# Altium schematic sheet TopSheet.schdoc(TopSheet)
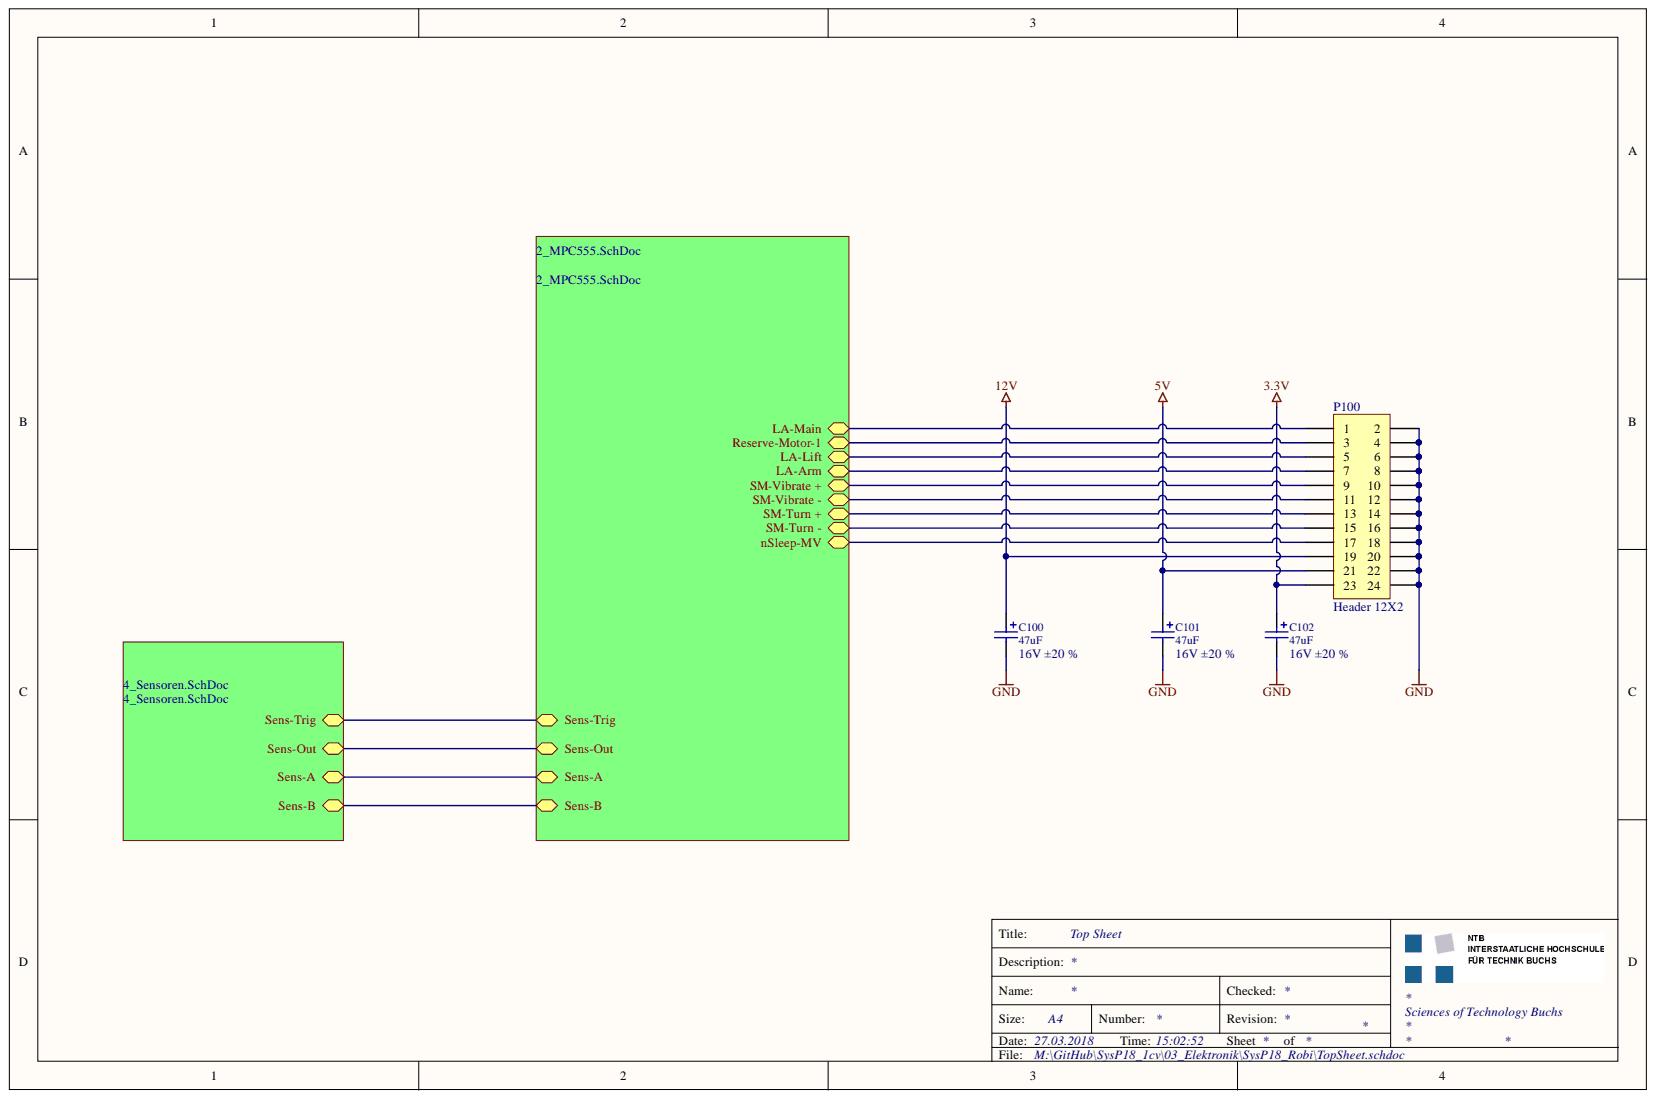
[diagram p0: TopSheet.schdoc]

## Parts
C100  value 47uF  [CDE_CAP AVE CODE D_H]  (hidden comment: AVE476M16D16T-F)
C101  value 47uF  [CDE_CAP AVE CODE D_H]  (hidden comment: AVE476M16D16T-F)
C102  value 47uF  [CDE_CAP AVE CODE D_H]  (hidden comment: AVE476M16D16T-F)
P100  Header 12X2  [HDR2X12]

## Nets
3.3V: C102.1 P100.23
5V: C101.1 P100.21
12V: C100.1 P100.19
GND: C100.2 C101.2 C102.2 P100.2 P100.4 P100.6 P100.8 P100.10 P100.12 P100.14 P100.16 P100.18 P100.20 P100.22 P100.24
NetP100_1: P100.1
NetP100_3: P100.3
NetP100_5: P100.5
NetP100_7: P100.7
NetP100_9: P100.9
NetP100_11: P100.11
NetP100_13: P100.13
NetP100_15: P100.15
NetP100_17: P100.17
Sens-A:
Sens-B:
Sens-Out:
Sens-Trig:

## Library data of the parts
C100-C102 = ELKO 47uF 16V: ELKO, 47uF, 16V, CDE_AVE476M16D16T-F_AVE CODE D; Manufacturer: Cornell Dubilier; ValueAddon: 16V ±20 %; RefFootprint: CDE_CAP AVE CODE D; #ClassSub: CAPACITOR; #Class: PASSIVE; RefSchema: CDE_AVE476M16D16T-F_AVE CODE D; Published: 20121220; Manufacturer PartNr: AVE476M16D16T-F; Library Name: IR-Sensor.SCHLIB; Distributor PartNr: 598-AVE476M16D16T-F; Distributor: Mouser; ComponentLink3Description: Packaging Specification; ComponentLink2URL: http://www.cde.com/catalogs/AVE.pdf; ComponentLink2Description: Datasheet; ComponentLink1Description: Manufacturer Link; Component Kind: Standard; ClassName: Connect_Full; Publisher: Stefan Ursella, M.Eng
P100 = Header 12X2: Header, 12-Pin, Dual row; Signal Integrity: Connector; Library Name: Miscellaneous Connectors.IntLib; LatestRevisionNote: Re-released for DXP Platform.; LatestRevisionDate: 17-Jul-2002; Component Kind: Standard; Publisher: Altium Limited
